# Altium schematic sheet Summits7Beta_Sch.SchDoc(Summits7Beta_Sch)
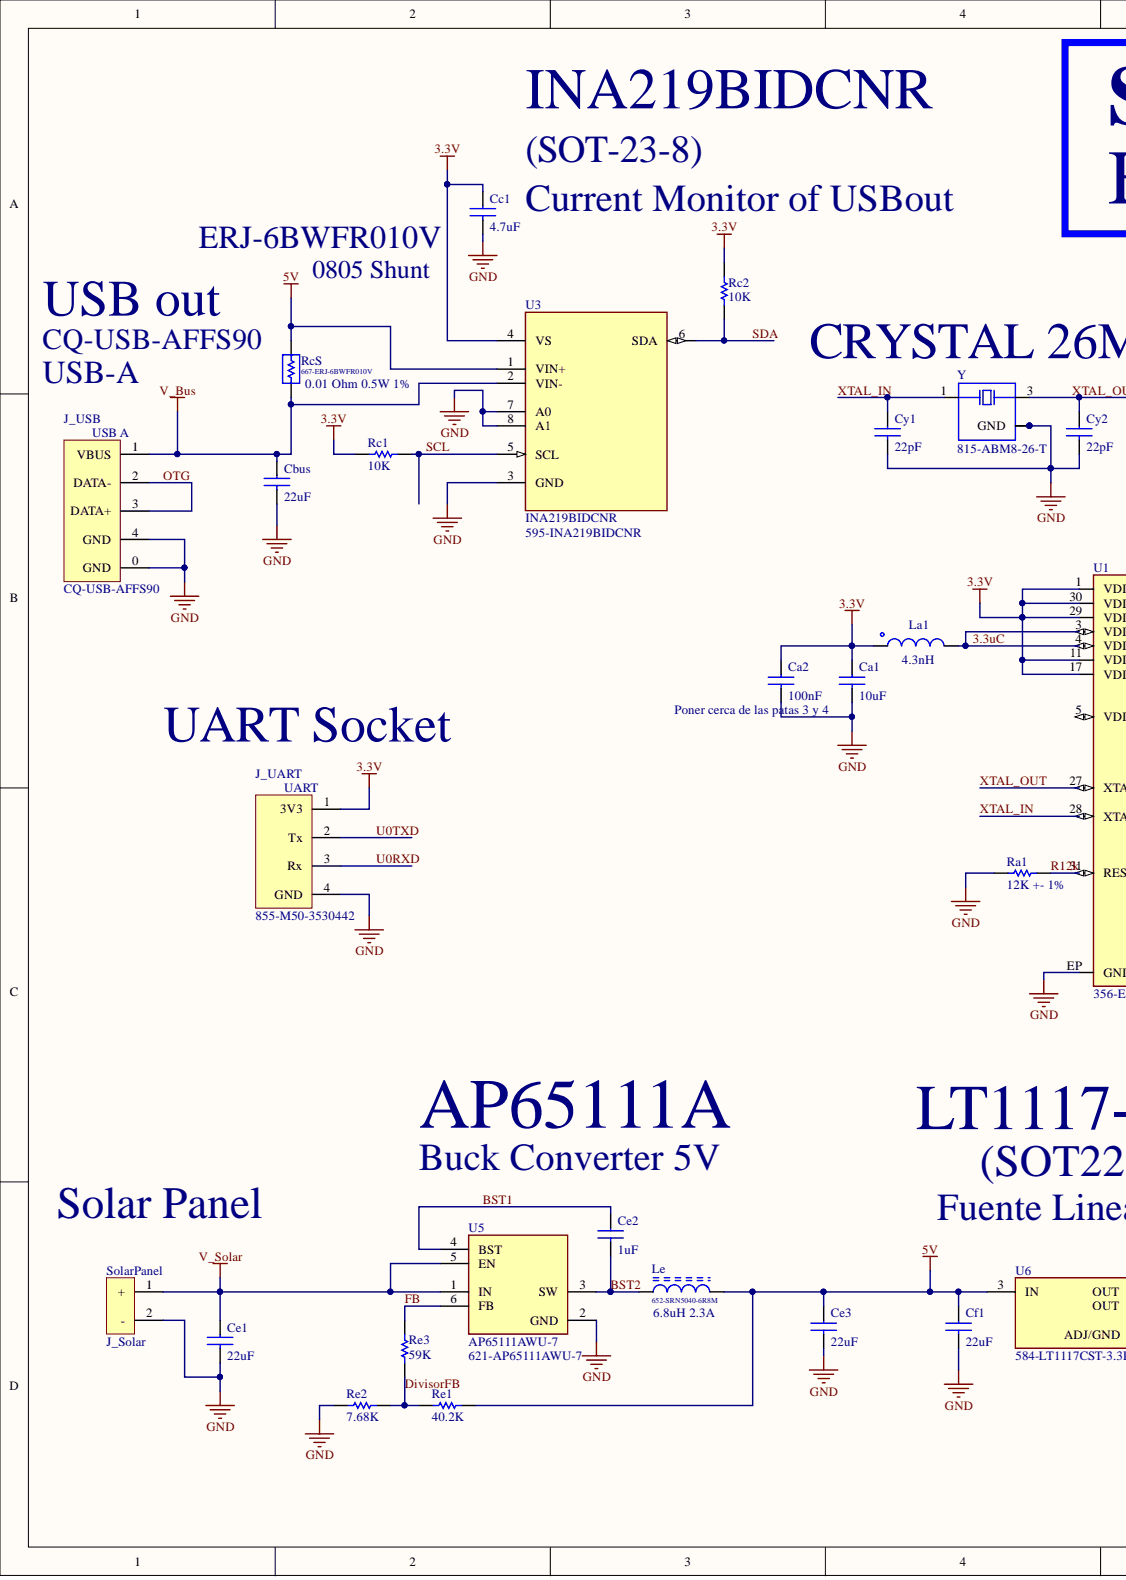
[diagram p0: Summits7Beta_Sch.SchDoc, left]
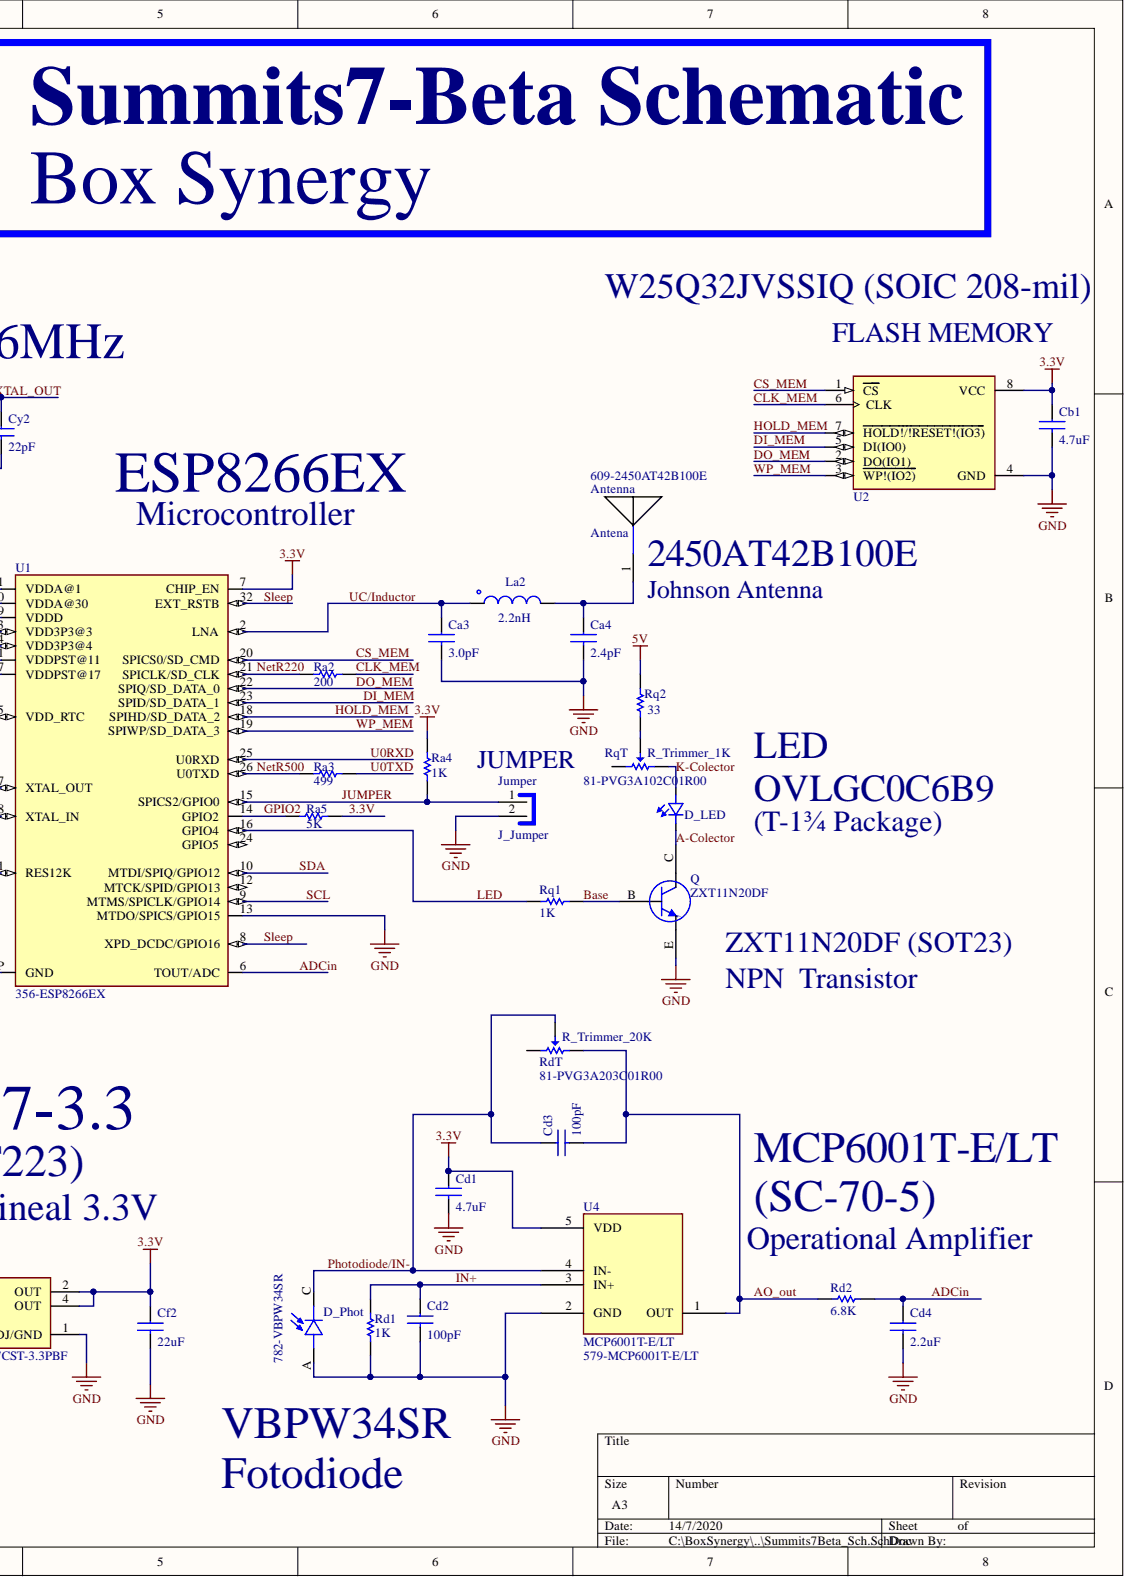
[diagram p1: Summits7Beta_Sch.SchDoc, right]

## Parts
Antenna  Supplier Part Number 1: 609-2450AT42B100E  [ANTENNA_CERAMIC_summits]  (hidden comment: AntennaCeramic)
Ca1  10uF  [CAP_0603]
Ca2  100nF  [CAP_0603]
Ca3  3.0pF  [CAP_0402]
Ca4  2.4pF  [CAP_0402]
Cb1  4.7uF  [CAP_0603]
Cbus  22uF  [CAP_1210]
Cc1  4.7uF  [CAP_0603]
Cd1  4.7uF  [CAP_0603]
Cd2  100pF  [CAP_0603]
Cd3  100pF  [CAP_0603]
Cd4  2.2uF  [CAP_0603]
Ce1  22uF  [CAP_1210]
Ce2  1uF  [CAP_0603]
Ce3  22uF  [CAP_1210]
Cf1  22uF  [CAP_0603]
Cf2  22uF  [CAP_0603]
Cy1  22pF  [CAP_0603]
Cy2  22pF  [CAP_0603]
D_LED  OVLGC0C6B9  [LED]
D_Phot  VBPW34S  Supplier Part Number 1: 782-VBPW34SR  [BPW34S]
J_Jumper  Jumper  [JUMPER-1.27 mm]
J_Solar  Connx2_Panel  [PANEL_IN]  (value not drawn)
J_UART  Supplier Part Number 1: 855-M50-3530442  [UART-1.27mm]  (hidden comment: Connx4_UART)
J_USB  CQ-USB-AFFS90  [USB-A_IPX8]
La1  4.3nH  [IND_0603]
La2  2.2nH  [IND_0402]
Le  6.8uH 2.3A  Supplier Part Number 1: 652-SRN5040-6R8M  [Inductor_SRN5040]
Q  ZXT11N20DF  [Transistor_SOT23-3]
Ra1  12K +- 1%  [RES_0603]
Ra2  200  [RES_0603]
Ra3  499  [RES_0603]
Ra4  1K  [RES_0603]
Ra5  5K  [RES_0603]
Rc1  10K  [RES_0603]
Rc2  10K  [RES_0603]
RcS  0.01 Ohm 0.5W 1%  Supplier Part Number 1: 667-ERJ-6BWFR010V  [RES-SHUNT-0805]
Rd1  1K  [RES_0603]
Rd2  6.8K  [RES_0603]
RdT  R_Trimmer_20K  Supplier Part Number 1: 81-PVG3A203C01R00  [RES_TRIMMER]
Re1  40.2K  [RES_0603]
Re2  7.68K  [RES_0603]
Re3  59K  [RES_0603]
Rq1  1K  [RES_0603]
Rq2  33  [RES_0603]
RqT  R_Trimmer_1K  [RES_TRIMMER]
U1  ESP8266EX  Supplier Part Number 1: 356-ESP8266EX  [ESP8266_QFN32-5X5MM]
U2  W25Q32JVSSIQ  [W25Q32JVSSIQ_SOIC127P790X216-8N]
U3  INA219BIDCNR  Supplier Part Number 1: 595-INA219BIDCNR  [INA219B SOT23]
U4  MCP6001T-E/LT  Supplier Part Number 1: 579-MCP6001T-E/LT  [MCP6001-SC70-5]
U5  AP65111AWU-7  Supplier Part Number 1: 621-AP65111AWU-7  [AP65111A-SOT23-6]
U6  Supplier Part Number 1: 584-LT1117CST-3.3PBF  [LT1117IST-3.3_SOT-223]  (hidden comment: LT1117IST-3.3)
Y  Supplier Part Number 1: 815-ABM8-26-T  [ABM8-26_OSCSC320X250X100N]  (hidden comment: ABM8-26MHz)

## Nets
5V: Ce3.1 Cf1.1 Le.1 RcS.1 Re1.1 Rq2.1 U3.1(VIN+) U6.3(IN)
GND: Ca1.2 Ca2.2 Ca3.2 Ca4.2 Cb1.2 Cbus.2 Cc1.2 Cd1.2 Cd2.2 Cd4.2 Ce1.2 Ce3.2 Cf1.2 Cf2.2 Cy1.2 Cy2.2 D_Phot.A J_Jumper.2 J_Solar.2 J_UART.4(GND) J_USB.0(GND) J_USB.4(GND) Q.E Ra1.2 Rd1.1 Re2.2 U1.13(MTDO/SPICS/GPIO15) U1.EP(GND) U2.4(GND) U3.3(GND) U3.7(A0) U3.8(A1) U4.2(GND) U5.2(GND) U6.1(ADJ/GND) Y.2(GND) Y.4
V_Bus: Cbus.1 J_USB.1(VBUS) RcS.2 U3.2(VIN-)
V_Solar: Ce1.1 J_Solar.1 U5.1(IN) U5.5(EN)
3.3uC: La1.2 U1.3(VDD3P3@3) U1.4(VDD3P3@4)
3.3V: Ca1.1 Ca2.1 Cb1.1 Cc1.1 Cd1.1 Cf2.1 J_UART.1(3V3) La1.1 Ra4.1 Ra5.1 Rc1.1 Rc2.1 U1.1(VDDA@1) U1.7(CHIP_EN) U1.11(VDDPST@11) U1.17(VDDPST@17) U1.29(VDDD) U1.30(VDDA@30) U2.8(VCC) U3.4(VS) U4.5(VDD) U6.2(OUT) U6.4(OUT)
A-Colector: D_LED.1 Q.C
ADCin: Cd4.1 Rd2.1 U1.6(TOUT/ADC)
AO_out: Cd3.2 Rd2.2 RdT.3 U4.1(OUT)
Base: Q.B Rq1.1
BST1: Ce2.1 U5.4(BST)
BST2: Ce2.2 Le.2 U5.3(SW)
CLK_MEM: Ra2.1 U2.6(CLK)
CS_MEM: U1.20(SPICS0/SD_CMD) U2.1(CS)
DI_MEM: U1.23(SPID/SD_DATA_1) U2.5(DI(IO0))
DivisorFB: Re1.2 Re2.1 Re3.2
DO_MEM: U1.22(SPIQ/SD_DATA_0) U2.2(DO(IO1))
FB: Re3.1 U5.6(FB)
GPIO2: Ra5.2 U1.14(GPIO2)
HOLD_MEM: U1.18(SPIHD/SD_DATA_2) U2.7(HOLD!/!RESET!(IO3))
IN+: Cd2.1 Rd1.2 U4.3(IN+)
JUMPER: J_Jumper.1 Ra4.2 U1.15(SPICS2/GPIO0)
K-Colector: D_LED.2 RqT.3
LED: Rq1.2 U1.16(GPIO4)
NetR220: Ra2.2 U1.21(SPICLK/SD_CLK)
NetR500: Ra3.2 U1.26(U0TXD)
OTG: J_USB.2(DATA-) J_USB.3(DATA+)
Photodiode/IN-: Cd3.1 D_Phot.C RdT.2 U4.4(IN-)
R12k: Ra1.1 U1.31(RES12K)
SCL: Rc1.2 U1.9(MTMS/SPICLK/GPIO14) U3.5(SCL)
SDA: Rc2.2 U1.10(MTDI/SPIQ/GPIO12) U3.6(SDA)
Sleep: U1.8(XPD_DCDC/GPIO16) U1.32(EXT_RSTB)
U0RXD: J_UART.3(Rx) U1.25(U0RXD)
U0TXD: J_UART.2(Tx) Ra3.1
UC/Inductor: Ca3.1 La2.1 U1.2(LNA)
WP_MEM: U1.19(SPIWP/SD_DATA_3) U2.3(WP!(IO2))
XTAL_IN: Cy1.1 U1.28(XTAL_IN) Y.1
XTAL_OUT: Cy2.1 U1.27(XTAL_OUT) Y.3
NetAntenna_1: Antenna.1 Ca4.1 La2.2
NetRq2_2: Rq2.2 RqT.2

Not connected: RdT 1; RqT 1; U1 5(VDD_RTC) 12(MTCK/SPID/GPIO13) 24(GPIO5)

## Library data of the parts
Antenna = AntennaCeramic: Antena de Cerámica; Supplier 1: Mouser; Component Kind: Standard; Library Name: Summits7Beta_LIB_Otros_Sch.SchLib
Ca1 Ca2 Cb1 Cc1 Cd1-Cd4 Ce2 Cf1 Cf2 Cy1 Cy2 = Cap_0603_Ceramic: Capac Ceramic SMD; Component Kind: Standard; Library Name: Summits7Beta_LIB_Pasivos_Sch.SchLib
Ca3 Ca4 = Cap_0402: Capac Ceramic SMD; Component Kind: Standard; Library Name: Summits7Beta_LIB_Pasivos_Sch.SchLib
Cbus Ce1 Ce3 = Cap_1210_Ceramic: Capac Ceramic SMD; Component Kind: Standard; Library Name: Summits7Beta_LIB_Pasivos_Sch.SchLib
D_LED = OVLGC0C6B9: LED Green-Blue 505nm; MP: HSMW-C191; MF: Broadcom Limited; Supplier Part Number 1: 828-OVLGC0C6B9; Supplier 1: Mouser; SnapEDA_Link: https://www.snapeda.com/parts/HSMW-C191/Broadcom%20Limited/view-part/1840124/?ref=snap; Price: None; Package: 0603 Broadcom; Component Kind: Standard; Availability: Unavailable; Library Name: Summits7Beta_LIB_Otros_Sch.SchLib
D_Phot = VBPW34S: Photodiode 850nm 25ns 120Â° 2-SMD, Gull Wing; MP: BPW 34 BS-Z; MF: OSRAM Opto; Supplier 1: Mouser; SnapEDA_Link: https://www.snapeda.com/parts/BPW%2034%20BS-Z/OSRAM%20Opto%20Semiconductors%20Inc./view-part/392328/?ref=snap; Price: None; Package: SMD-2 Osram Opto; Component Kind: Standard; Availability: Unavailable; Library Name: Summits7Beta_LIB_Otros_Sch.SchLib
J_Jumper = Jumper: 2.54mm Pin Header 2x1; Supplier Part Number 1: 855-M20-9990245; Supplier 1: Mouser; Component Kind: Standard; Library Name: Frost5000Beta_LIB_Conectores_Sch.SchLib
J_Solar = Connx2_Panel: Conector del Panel; Component Kind: Standard; Library Name: Summits7Beta_LIB_Conectores_Sch.SchLib
J_UART = Connx4_UART: 1.27mm Pin Header 4x1; Supplier 1: Mouser; Component Kind: Standard; Library Name: Summits7Beta_LIB_Conectores_Sch.SchLib
J_USB = USB-A 5V: Conector del USB   --   CQ-USB-AFFS90; Component Kind: Standard; Library Name: Summits7Beta_LIB_Conectores_Sch.SchLib
La1 = Ind_0603: Inductor SMD 0603; MP: LQP03TN62NHZ2D; MF: Murata; SnapEDA_Link: https://www.snapeda.com/parts/LQP03TN62NHZ2D/Murata/view-part/4472751/?ref=snap; Price: None; Package: 0603 Murata; Component Kind: Standard; Availability: Unavailable; Library Name: Summits7Beta_LIB_Pasivos_Sch.SchLib
La2 = Ind_0402: Inductor SMD 0402; MP: LQP03TN62NHZ2D; MF: Murata; SnapEDA_Link: https://www.snapeda.com/parts/LQP03TN62NHZ2D/Murata/view-part/4472751/?ref=snap; Price: None; Package: 0603 Murata; Component Kind: Standard; Availability: Unavailable; Library Name: Summits7Beta_LIB_Pasivos_Sch.SchLib
Le = Ind_SRN5040: Inductor_SRN5040; MF: Vishay Dale; Supplier 1: Mouser; SnapEDA_Link: https://www.snapeda.com/parts/IHLP-2525CZ-01/Vishay%20Dale/view-part/561997/?ref=snap; Price: None; Package: Nonstandard Vishay Dale; Component Kind: Standard; Availability: Unavailable; Library Name: Summits7Beta_LIB_Pasivos_Sch.SchLib
Q = ZXT11N20DF: Transistor NPN Low Saturation Vce; Supplier Part Number 1: 522-ZXT11N20DFTA; Supplier 1: Mouser; Component Kind: Standard; Library Name: Summits7Beta_LIB_Integrados_Sch.SchLib
Ra1-Ra5 Rc1 Rc2 Rd1 Rd2 Re1-Re3 Rq1 Rq2 = Res_0603: Resistor SMD; Simulation: RESISTOR; Signal Integrity: Res; Published: 8-Jun-2000; PackageReference: AXIAL-0.3; PackageDescription: Axial Device, Thru-Hole; 2 Leads; 0.3 in Pin Spacing; LatestRevisionNote: Re-released for DXP Platform.; LatestRevisionDate: 17-Jul-2002; Component Kind: Standard; Publisher: Altium Limited; Library Name: Summits7Beta_LIB_Pasivos_Sch.SchLib
RcS = Shunt_0805: Shunt; Supplier 1: Mouser; Simulation: RESISTOR; Signal Integrity: Res; Published: 8-Jun-2000; PackageReference: AXIAL-0.3; PackageDescription: Axial Device, Thru-Hole; 2 Leads; 0.3 in Pin Spacing; LatestRevisionNote: Re-released for DXP Platform.; LatestRevisionDate: 17-Jul-2002; Component Kind: Standard; Publisher: Altium Limited; Library Name: Summits7Beta_LIB_Pasivos_Sch.SchLib
RdT = R_Trimmer_20k: Trimmer Resistor SMD 20kohm; Supplier 1: Mouser; Simulation: RESISTOR; Signal Integrity: Res; Published: 8-Jun-2000; PackageReference: AXIAL-0.3; PackageDescription: Axial Device, Thru-Hole; 2 Leads; 0.3 in Pin Spacing; LatestRevisionNote: Re-released for DXP Platform.; LatestRevisionDate: 17-Jul-2002; Component Kind: Standard; Publisher: Altium Limited; Library Name: Summits7Beta_LIB_Pasivos_Sch.SchLib
RqT = R_Trimmer_1k: Trimmer Resistor SMD 1kohm; Supplier Part Number 1: 81-PVG3A102C01R00; Supplier 1: Mouser; Simulation: RESISTOR; Signal Integrity: Res; Published: 8-Jun-2000; PackageReference: AXIAL-0.3; PackageDescription: Axial Device, Thru-Hole; 2 Leads; 0.3 in Pin Spacing; LatestRevisionNote: Re-released for DXP Platform.; LatestRevisionDate: 17-Jul-2002; Component Kind: Standard; Publisher: Altium Limited; Library Name: Summits7Beta_LIB_Pasivos_Sch.SchLib
U1 = ESP8266EX: Microcontrolador ESP8266; MF: Espressif Systems; Supplier 1: Mouser; SnapEDA_Link: https://www.snapeda.com/parts/ESP8266/Espressif%20Systems/view-part/106411/?ref=snap; Price: None; Package: VFQFN-32 Espressif Systems; MP: ESP8266; Component Kind: Standard; Availability: Unavailable; Library Name: Summits7Beta_LIB_Integrados_Sch.SchLib
U2 = W25Q32JVSSIQ: FLASH - NOR Memory IC 32Mb _4M x 8_ SPI - Quad I/O 133MHz 8-SOIC; MF: Winbond; SnapEDA_Link: https://www.snapeda.com/parts/W25Q32JVSSIQ/Winbond%20Electronics/view-part/596953/?ref=snap; Price: None; Package: SOIC-8 Winbond; MP: W25Q32JVSSIQ; Component Kind: Standard; Availability: Unavailable; Library Name: Summits7Beta_LIB_Integrados_Sch.SchLib
U3 = INA219BIDCNR: Current Monitor Regulator High-Side 10mA SOT-23-8; MF: Texas Instruments; Supplier 1: Mouser; SnapEDA_Link: https://www.snapeda.com/parts/INA219BIDCNR/Texas%20Instruments/view-part/870494/?ref=snap; Package: SOT-23 Texas Instruments; MP: INA219BIDCNR; Digi-Key_Purchase_URL: https://www.digikey.com.au/product-detail/en/texas-instruments/INA219BIDCNR/296-46420-1-ND/7033594?utm_source=snapeda&utm_medium=aggregator&utm_campaign=symbol; Digi-Key_Part_Number: 296-46420-1-ND; Component Kind: Standard; Library Name: Summits7Beta_LIB_Integrados_Sch.SchLib
U4 = MCP6001T-E/LT: OP AMP - 1.8V 1MHz  Single Supply; Supplier 1: Mouser; Component Kind: Standard; Library Name: Summits7Beta_LIB_Integrados_Sch.SchLib
U5 = AP65111AWU-7: Buck Switching Regulator IC Positive Adjustable 0.8V 1 Output 1.5A SOT-23-6 Thin, TSOT-23-6; MF: Diodes Inc.; Supplier 1: Mouser; SnapEDA_Link: https://www.snapeda.com/parts/AP65111AWU-7/Diodes%20Inc./view-part/1631258/?ref=snap; Price: None; Package: TSOT-23-6 Diodes Inc.; MP: AP65111AWU-7; Component Kind: Standard; Availability: Unavailable; Library Name: Summits7Beta_LIB_Integrados_Sch.SchLib
U6 = LT1117IST-3.3: LDO Regulator Pos 3.3V 0.8A Automotive 4-Pin_3+Tab_ SOT-223 Tube; MP: LT1117IST-5#PBF; MF: Analog Devices; Supplier 1: Mouser; SnapEDA_Link: https://www.snapeda.com/parts/LT1117IST-5%23PBF/Analog%20Devices/view-part/4348177/?ref=snap; Price: None; Package: SOT-223-3 Analog Devices; Component Kind: Standard; Availability: Unavailable; Library Name: Summits7Beta_LIB_Integrados_Sch.SchLib
Y = ABM8-26MHz: 26MHz Â±10ppm Crystal 10pF 50 Ohms 4-SMD, No Lead; MP: ABM8-26.000MHZ-10-1-U-T; MF: Abracon; Supplier 1: Mouser; SnapEDA_Link: https://www.snapeda.com/parts/ABM8-26.000MHZ-10-1-U-T/Abracon%20LLC/view-part/356267/?ref=snap; Package: SMD-4 Abracon; Digi-Key_Purchase_URL: https://www.digikey.com/product-detail/en/abracon-llc/ABM8-26.000MHZ-10-1-U-T/300-8230-1-ND/687923?utm_source=snapeda&utm_medium=aggregator&utm_campaign=symbol; Digi-Key_Part_Number: 300-8230-1-ND; Component Kind: Standard; Library Name: Summits7Beta_LIB_Otros_Sch.SchLib
